# Altium schematic sheet Knot_Thing_USB_Power.SchDoc
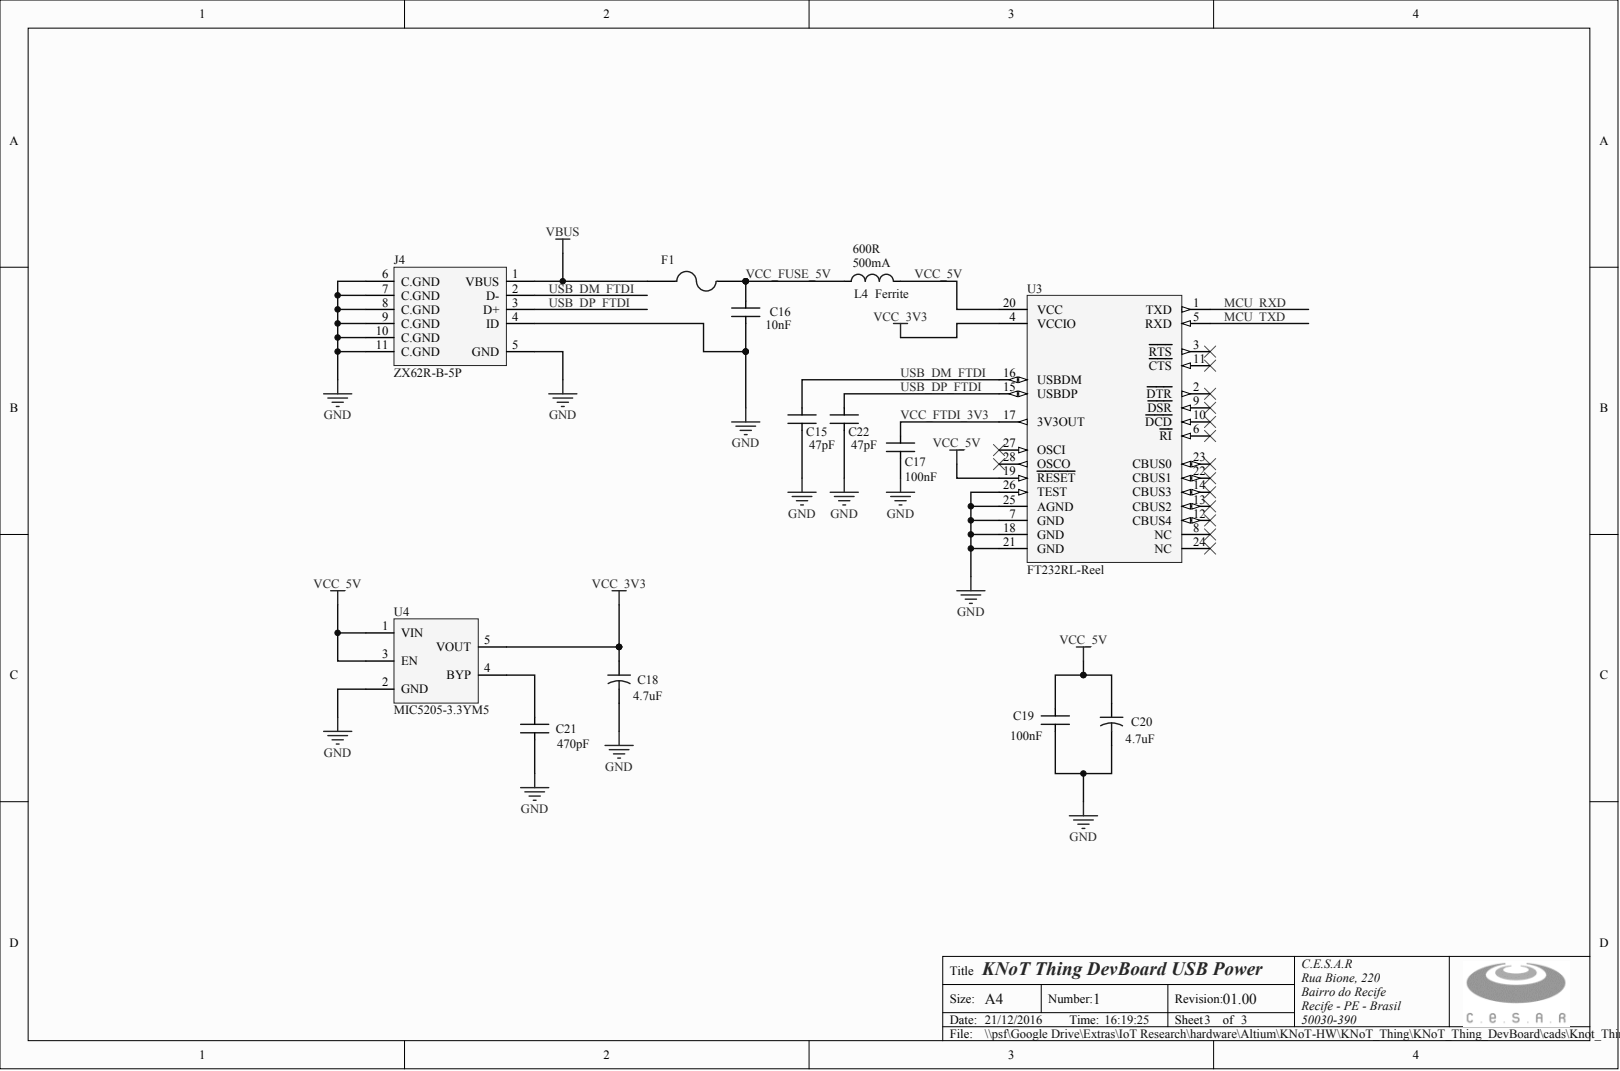
[diagram p0: Knot_Thing_USB_Power.SchDoc]

## Parts
C15  value 47pF  (hidden comment: Cap_0402)
C16  value 10nF  (hidden comment: Cap_0402)
C17  value 100nF  (hidden comment: Cap_0402)
C18  value 4.7uF  (hidden comment: Cap0603)
C19  value 100nF  (hidden comment: Cap_0402)
C20  value 4.7uF  (hidden comment: Cap0603)
C21  value 470pF  (hidden comment: Cap_0402)
C22  value 47pF  (hidden comment: Cap_0402)
F1  0402L050SLKR  (value not drawn)
J4  ZX62R-B-5P
L4  Ferrite  Res: 600R  Cur: 500mA
U3  FT232RL-Reel
U4  MIC5205-3.3YM5

## Nets
GND: C15.1 C16.1 C17.2 C18.2 C19.2 C20.2 C21.1 C22.1 J4.4(ID) J4.5(GND) J4.6(C.GND) J4.7(C.GND) J4.8(C.GND) J4.9(C.GND) J4.10(C.GND) J4.11(C.GND) U3.7(GND) U3.18(GND) U3.21(GND) U3.25(AGND) U3.26(TEST) U4.2(GND)
VBUS: F1.1 J4.1(VBUS)
VCC_3V3: C18.1 U3.4(VCCIO) U4.5(VOUT)
MCU_RXD: U3.1(TXD)
MCU_TXD: U3.5(RXD)
USB_DM_FTDI: C15.2 J4.2(D-) U3.16(USBDM)
USB_DP_FTDI: C22.2 J4.3(D+) U3.15(USBDP)
VCC_5V: C19.1 C20.1 L4.2 U3.19(RESET) U3.20(VCC) U4.1(VIN) U4.3(EN)
VCC_FTDI_3V3: C17.1 U3.17(3V3OUT)
VCC_FUSE_5V: C16.2 F1.2 L4.1
NetC21_2: C21.2 U4.4(BYP)

Not connected: U3 2(DTR) 3(RTS) 6(RI) 8(NC) 9(DSR) 10(DCD) 11(CTS) 12(CBUS4) 13(CBUS2) 14(CBUS3) 22(CBUS1) 23(CBUS0) 24(NC) 27(OSCI) 28(OSCO)

## Library data of the parts
F1 = (no symbol name): PartNumb: F5759DKR-ND; Supplier 1: Digi-Key; Supplier Part Number 1: F5759CT-ND
U3 = (no symbol name): Manufacturer: FTDI; DatasheetVersion: ver 2.10, Mar-2012; PackageVersion: Mar-12; PackageReference: SSOP-28; PackageDescription: 28-Pin Small Outline Package 0.65 mm Pitch; PartNumber: FT232RL-Reel; ComponentLink1Description: Manufacturer URL; ComponentLink1URL: http://www.ftdichip.com/; ComponentLink2Description: Datasheet; ComponentLink2URL: http://www.ftdichip.com/Support/Documents/DataSheets/ICs/DS_FT232R.pdf; Supplier 1: Digi-Key; Supplier Part Number 1: 768-1007-1-ND
C15-C22 J4 L4 U4 = (no symbol name): Supplier 1: Digi-Key
  C15 C22: Supplier Part Number 1: 311-1021-1-ND
  C16: Supplier Part Number 1: 311-1703-1-ND
  C17 C19: Supplier Part Number 1: 311-1731-1-ND
  C18 C20: Published: 23-Sep-2002; PackageDescription: Capacitor; 2 Leads; Supplier Part Number 1: 311-1453-1-ND; Publisher: Altium Limited
  C21: Supplier Part Number 1: 311-1028-1-ND
  J4: Supplier Part Number 1: H125274CT-ND
  L4: Supplier Part Number 1: 445-2166-1-ND
  U4: Supplier Part Number 1: 576-1259-1-ND
